# Altium schematic sheet Main.SchDoc
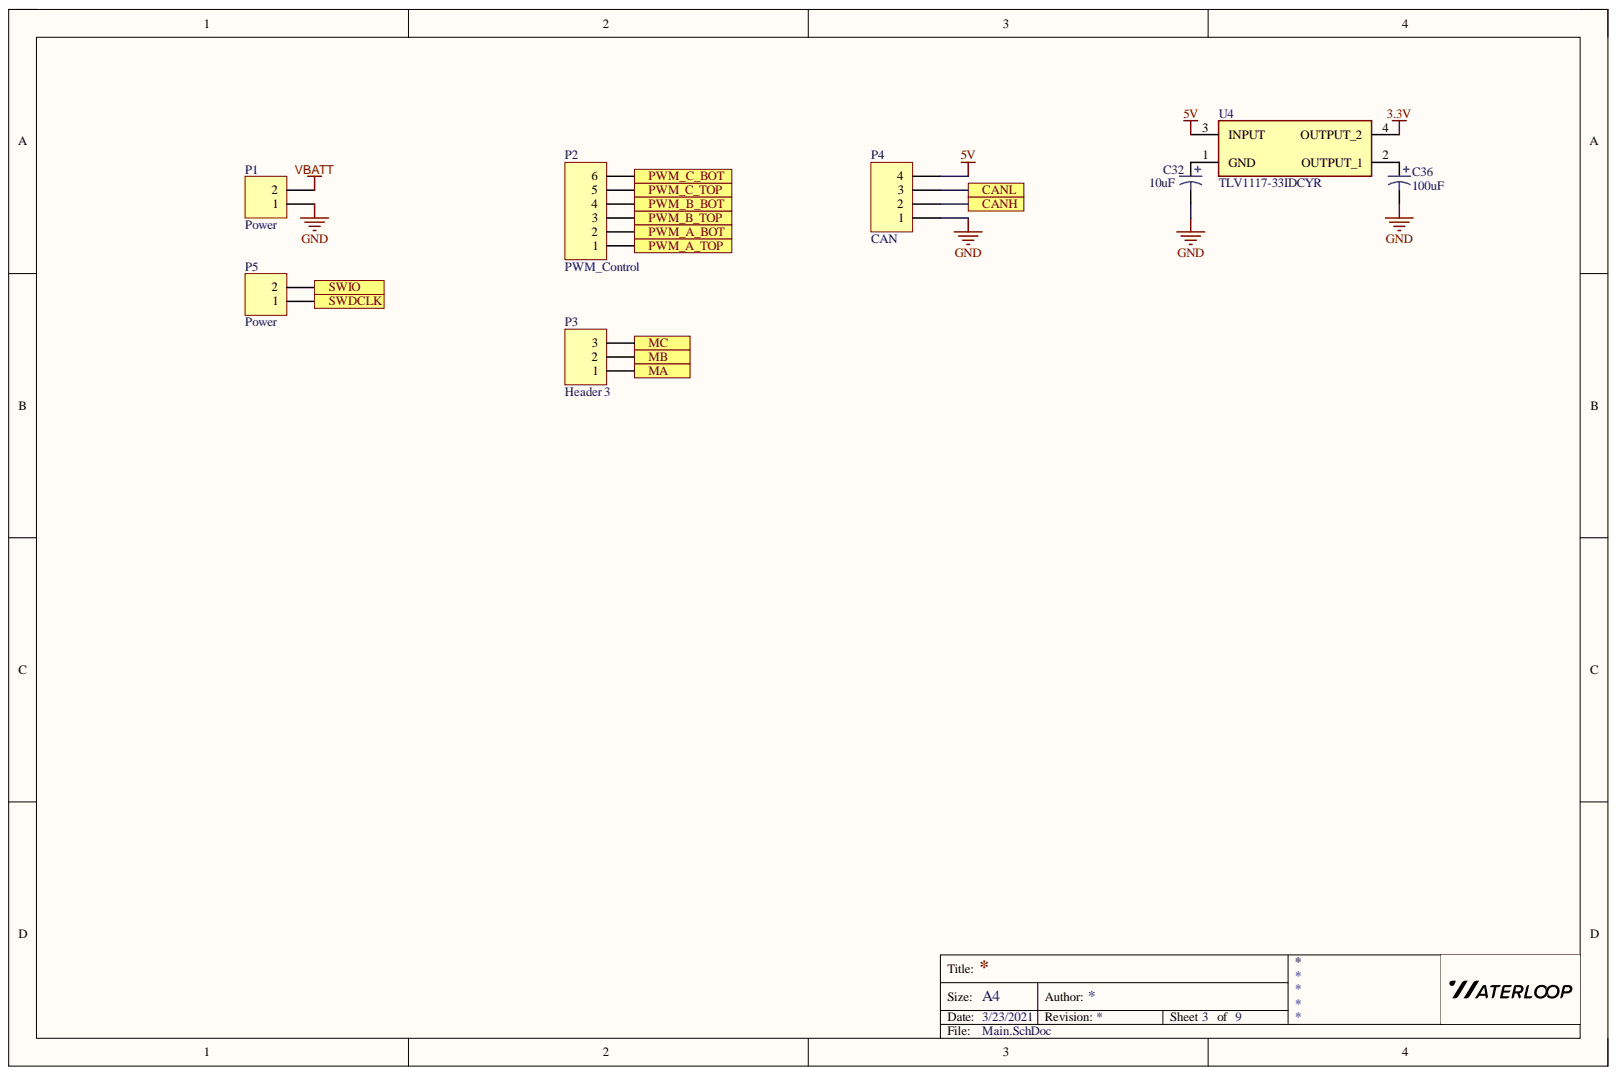
[diagram p0: Main.SchDoc]

## Parts
C32  value 10uF  [CAPAE660X800N]  (hidden comment: Cap Pol3)
C36  value 100uF  [CAPAE660X800N]  (hidden comment: Cap Pol3)
P1  Power  [HDR1X2]
P2  PWM_Control  [HDR1X6]
P3  Header 3  [HDR1X3]
P4  CAN  [HDR1X4]
P5  Power  [HDR1X2]
U4  TLV1117-33IDCYR  [SOT230P700X180-4N]

## Ports
CANH, CANL, MA, MB, MC, PWM_A_BOT, PWM_A_TOP, PWM_B_BOT, PWM_B_TOP, PWM_C_BOT, PWM_C_TOP, SWDCLK, SWIO

## Nets
3.3V: U4.4(OUTPUT_2)
5V: P4.4 U4.3(INPUT)
GND: C32.2 C36.2 P1.1 P4.1
VBATT: P1.2
CANH: P4.2 ; port CANH
CANL: P4.3 ; port CANL
MA: P3.1 ; port MA
MB: P3.2 ; port MB
MC: P3.3 ; port MC
PWM_A_BOT: P2.2 ; port PWM_A_BOT
PWM_A_TOP: P2.1 ; port PWM_A_TOP
PWM_B_BOT: P2.4 ; port PWM_B_BOT
PWM_B_TOP: P2.3 ; port PWM_B_TOP
PWM_C_BOT: P2.6 ; port PWM_C_BOT
PWM_C_TOP: P2.5 ; port PWM_C_TOP
SWDCLK: P5.1 ; port SWDCLK
SWIO: P5.2 ; port SWIO
NetC32_1: C32.1 U4.1(GND)
NetC36_1: C36.1 U4.2(OUTPUT_1)

## Library data of the parts
C32 C36 = Cap Pol3: Polarized Capacitor (Surface Mount); Component Kind: Standard; LatestRevisionDate: 29-May-2009; LatestRevisionNote: IPC-7351 Footprint Added.; PackageDescription: Chip Capacitor; PackageReference: C0805; Published: 8-Jun-2000; Signal Integrity: Cap Pol; Simulation: CAP; Publisher: Altium Limited
  C32: Library Name: Miscellaneous Connectors.IntLib
  C36: Library Name: Miscellaneous Devices.IntLib
P1 P5 = Header 2: Header, 2-Pin; Component Kind: Standard; LatestRevisionDate: 17-Jul-2002; LatestRevisionNote: Re-released for DXP Platform.; Library Name: Miscellaneous Connectors.IntLib; Signal Integrity: Connector; Publisher: Altium Limited
P2 = Header 6: Header, 6-Pin; Component Kind: Standard; LatestRevisionDate: 17-Jul-2002; LatestRevisionNote: Re-released for DXP Platform.; Library Name: Miscellaneous Connectors.IntLib; Signal Integrity: Connector; Publisher: Altium Limited
P3 = Header 3: Header, 3-Pin; Component Kind: Standard; LatestRevisionDate: 17-Jul-2002; LatestRevisionNote: Re-released for DXP Platform.; Library Name: Miscellaneous Connectors.IntLib; Signal Integrity: Connector; Publisher: Altium Limited
P4 = Header 4: Header, 4-Pin; Component Kind: Standard; LatestRevisionDate: 17-Jul-2002; LatestRevisionNote: Re-released for DXP Platform.; Library Name: Miscellaneous Connectors.IntLib; Signal Integrity: Connector; Publisher: Altium Limited
U4 = TLV1117-33IDCYR: Integrated Circuit; Manufacturer_Name: Texas Instruments; Arrow Part Number: TLV1117-33IDCYR; Arrow Price/Stock: https://www.arrow.com/en/products/tlv1117-33idcyr/texas-instruments; Component Kind: Standard; Datasheet Link: http://www.ti.com/lit/ds/symlink/tlv1117.pdf?HQS=TI-null-null-digikeymode-df-pf-null-wwe&ts=1590668900314; Height: 1.8mm; Library Name: Motor Controller.SchLib; Manufacturer_Part_Number: TLV1117-33IDCYR; Mouser Part Number: 595-TLV1117-33IDCYR; Mouser Price/Stock: https://www.mouser.co.uk/ProductDetail/Texas-Instruments/TLV1117-33IDCYR?qs=p6lVfQR1GSoKgp3tAXcW5w%3D%3D
